# Altium schematic sheet Page 5.SchDoc("Page 5")
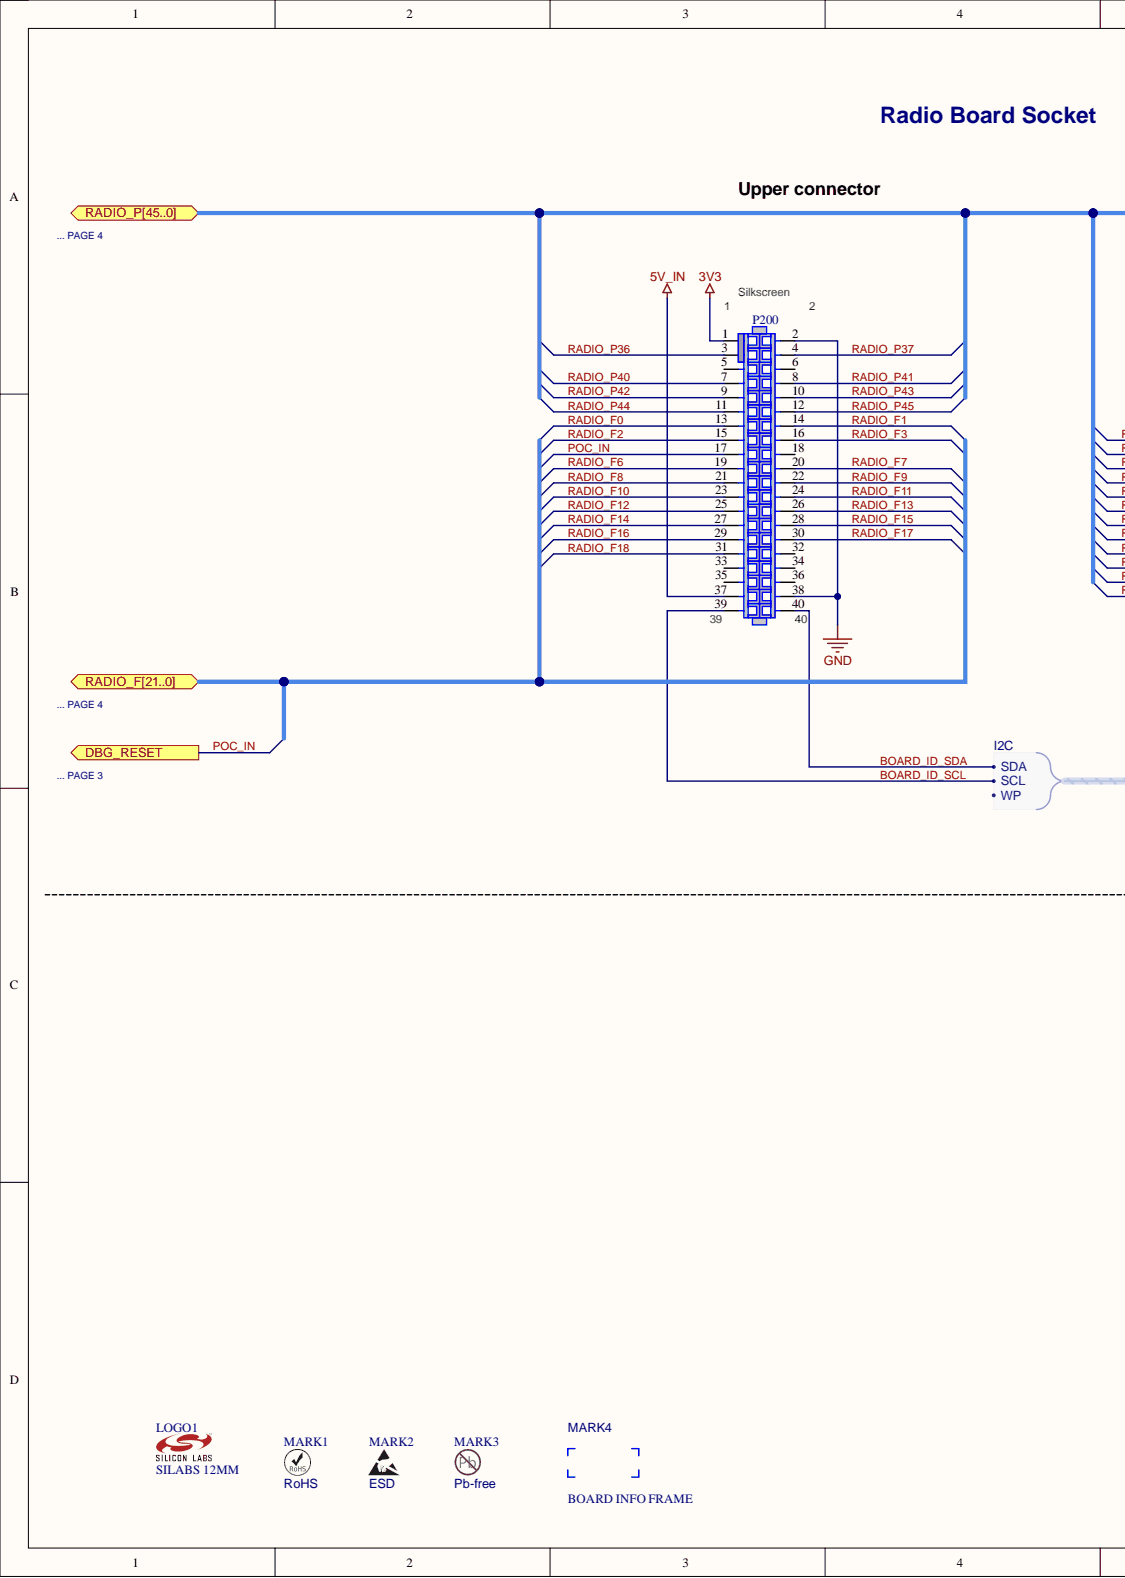
[diagram p0: Page 5.SchDoc, left]
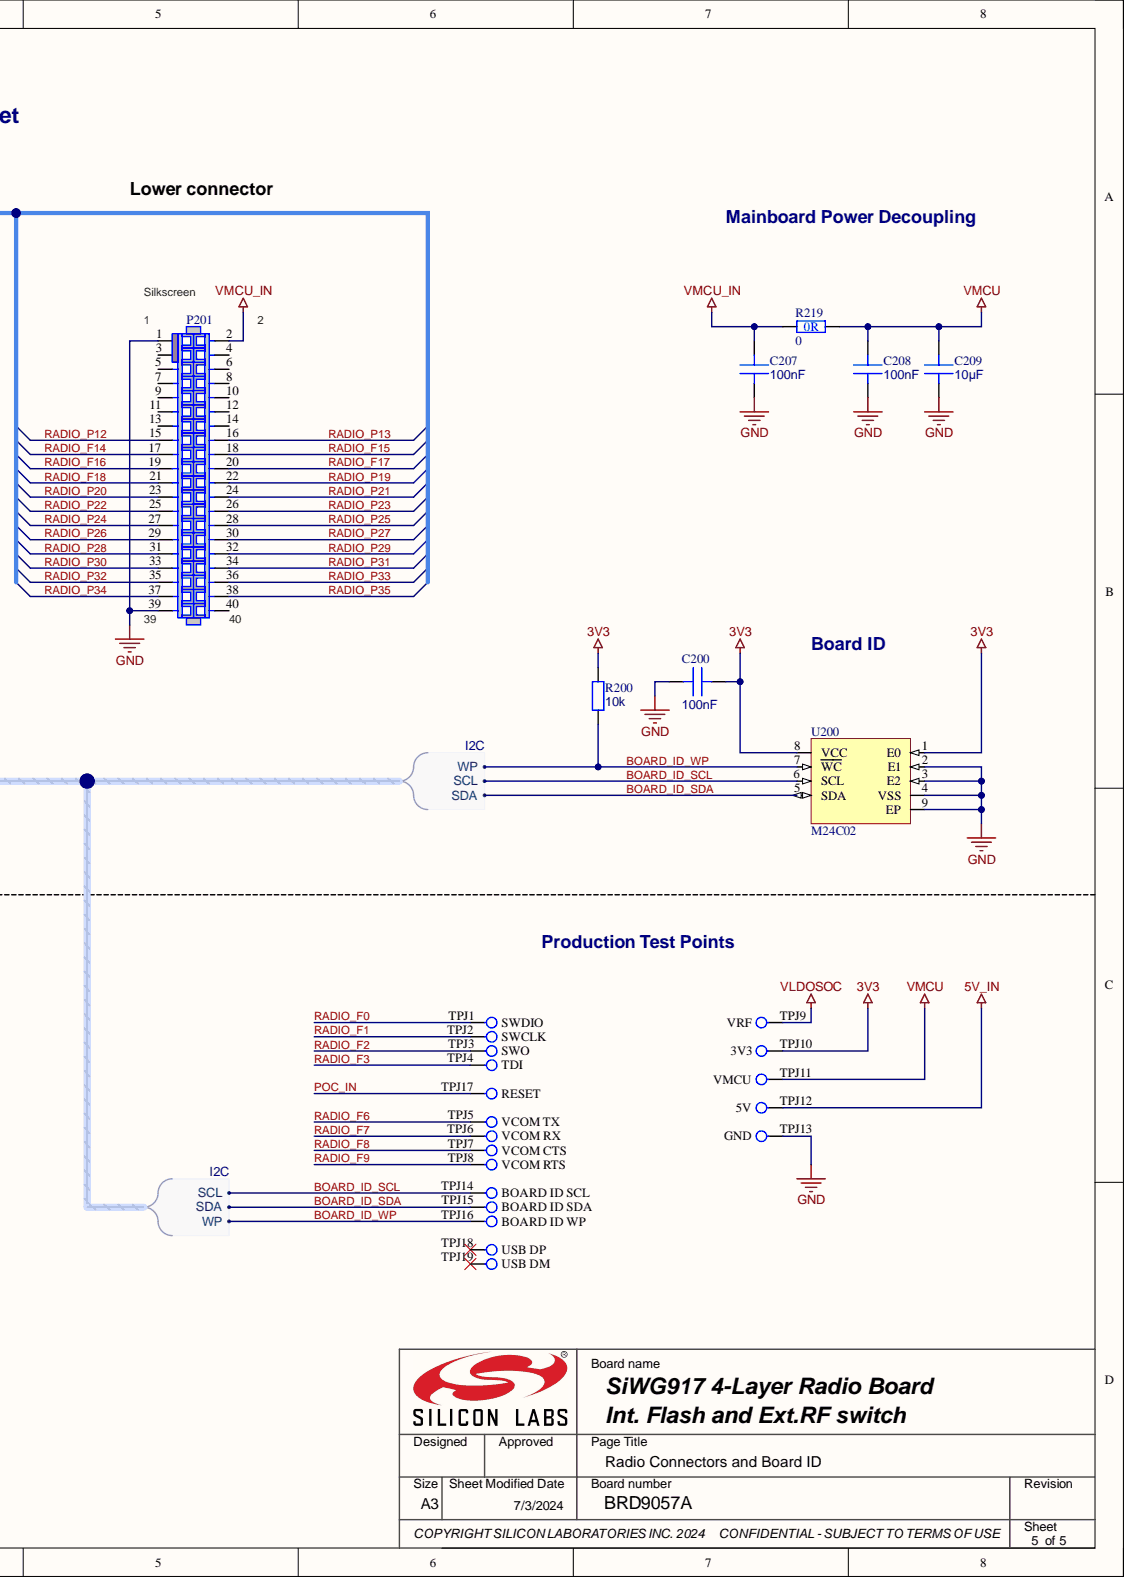
[diagram p1: Page 5.SchDoc, right]

## Parts
C200  value 100nF  [C0201_GM]  (hidden comment: CAP CER 0201 X5R 100nF 16V 10%)
C207  value 100nF  [C0201_GM]  (hidden comment: CAP CER 0201 X5R 100nF 16V 10%)
C208  value 100nF  [C0201_GM]  (hidden comment: CAP CER 0201 X5R 100nF 16V 10%)
C209  value 10µF  [C0603]  (hidden comment: CAP CER 0603 X5R 10uF 16V 10%)
LOGO1  value SILABS 12MM  [LOGO_SILABS_W12.0_SILK]  (hidden comment: LOGO SILABS 12MM)  (no BOM)
M1  RB TEST FIXTURE PATTERN  [RB TEST FIXTURE PATTERN]  (value not drawn)  (no BOM)
MARK1  value RoHS  [LOGO RoHS 5MM]  (hidden comment: LOGO RoHS 5MM)  (no BOM)
MARK2  value ESD  [LOGO ESD 4.5MM]  (hidden comment: LOGO ESD 4.5MM)  (no BOM)
MARK3  value Pb-free  [LOGO PBF 2MM]  (hidden comment: LOGO PBF 2MM)  (no BOM)
MARK4  BOARD INFO FRAME 19MM  value BOARD INFO FRAME  [BOARD INFO FRAME SILK-Footprint-1]  (no BOM)
P200  2344-220MS3CUNR8  [SAMTEC_SFC-120-T2-L-D-A]  (value not drawn)
P201  2344-220MS3CUNR8  [SAMTEC_SFC-120-T2-L-D-A]  (value not drawn)
R200  value 10k  [R0201]  (hidden comment: RES 0201 10K 1/20W 1% 200ppm)
R219  value 0  [R0402]  (hidden comment: RES 0402 0R)
U200  value M24C02  [DFN50P300X200X60_HS-9N_GM]  (hidden comment: M24C02-FMC6TG)

## Ports
DBG_RESET, RADIO_F0, RADIO_F1, RADIO_F2, RADIO_F3, RADIO_F4, RADIO_F5, RADIO_F6, RADIO_F7, RADIO_F8, RADIO_F9, RADIO_F10, RADIO_F11, RADIO_F12, RADIO_F13, RADIO_F14, RADIO_F15, RADIO_F16, RADIO_F17, RADIO_F18, RADIO_F19, RADIO_F20, RADIO_F21, RADIO_F[21..0], RADIO_P0, RADIO_P1, RADIO_P2, RADIO_P3, RADIO_P4, RADIO_P5, RADIO_P6, RADIO_P7, RADIO_P8, RADIO_P9, RADIO_P10, RADIO_P11, RADIO_P12, RADIO_P13, RADIO_P14, RADIO_P15, RADIO_P16, RADIO_P17, RADIO_P18, RADIO_P19, RADIO_P20, RADIO_P21, RADIO_P22, RADIO_P23, RADIO_P24, RADIO_P25, RADIO_P26, RADIO_P27, RADIO_P28, RADIO_P29, RADIO_P30, RADIO_P31, RADIO_P32, RADIO_P33, RADIO_P34, RADIO_P35, RADIO_P36, RADIO_P37, RADIO_P38, RADIO_P39, RADIO_P40, RADIO_P41, RADIO_P42, RADIO_P43, RADIO_P44, RADIO_P45, RADIO_P[45..0]

## Nets
3V3: C200.1 M1.TPJ10(3V3) P200.1 R200.2 U200.1(E0) U200.8(VCC)
5V_IN: M1.TPJ12(5V) P200.37
GND: C200.2 C207.1 C208.1 C209.2 M1.TPJ13(GND) P200.2 P200.38 P201.1 P201.39 U200.2(E1) U200.3(E2) U200.4(VSS) U200.9(EP)
VLDOSOC: M1.TPJ9(VRF)
VMCU: C208.2 C209.1 M1.TPJ11(VMCU) R219.2
VMCU_IN: C207.2 P201.2 R219.1
BOARD_ID_SCL: M1.TPJ14(BOARD_ID_SCL) P200.39 U200.6(SCL)
BOARD_ID_SDA: M1.TPJ15(BOARD_ID_SDA) P200.40 U200.5(SDA)
BOARD_ID_WP: M1.TPJ16(BOARD_ID_WP) R200.1 U200.7(WC)
RADIO_F0: M1.TPJ1(SWDIO) P200.13 ; port RADIO_F[21..0]
RADIO_F1: M1.TPJ2(SWCLK) P200.14 ; port RADIO_F[21..0]
RADIO_F2: M1.TPJ3(SWO) P200.15 ; port RADIO_F[21..0]
RADIO_F3: M1.TPJ4(TDI) P200.16 ; port RADIO_F[21..0]
RADIO_F4: M1.TPJ17(RESET) P200.17 ; port DBG_RESET, port RADIO_F[21..0]
RADIO_F6: M1.TPJ5(VCOM_TX) P200.19 ; port RADIO_F[21..0]
RADIO_F7: M1.TPJ6(VCOM_RX) P200.20 ; port RADIO_F[21..0]
RADIO_F8: M1.TPJ7(VCOM_CTS) P200.21 ; port RADIO_F[21..0]
RADIO_F9: M1.TPJ8(VCOM_RTS) P200.22 ; port RADIO_F[21..0]
RADIO_F10: P200.23 ; port RADIO_F[21..0]
RADIO_F11: P200.24 ; port RADIO_F[21..0]
RADIO_F12: P200.25 ; port RADIO_F[21..0]
RADIO_F13: P200.26 ; port RADIO_F[21..0]
RADIO_F14: P200.27 ; port RADIO_F[21..0]
RADIO_F14: P200.27 ; port RADIO_F[21..0]
RADIO_F15: P200.28 ; port RADIO_F[21..0]
RADIO_F15: P200.28 ; port RADIO_F[21..0]
RADIO_F16: P200.29 ; port RADIO_F[21..0]
RADIO_F16: P200.29 ; port RADIO_F[21..0]
RADIO_F17: P200.30 ; port RADIO_F[21..0]
RADIO_F17: P200.30 ; port RADIO_F[21..0]
RADIO_F18: P200.31 ; port RADIO_F[21..0]
RADIO_F18: P200.31 ; port RADIO_F[21..0]
RADIO_P12: P201.15 ; port RADIO_P[45..0]
RADIO_P13: P201.16 ; port RADIO_P[45..0]
RADIO_P14: P201.17 ; port RADIO_P[45..0]
RADIO_P15: P201.18 ; port RADIO_P[45..0]
RADIO_P16: P201.19 ; port RADIO_P[45..0]
RADIO_P17: P201.20 ; port RADIO_P[45..0]
RADIO_P18: P201.21 ; port RADIO_P[45..0]
RADIO_P19: P201.22 ; port RADIO_P[45..0]
RADIO_P20: P201.23 ; port RADIO_P[45..0]
RADIO_P21: P201.24 ; port RADIO_P[45..0]
RADIO_P22: P201.25 ; port RADIO_P[45..0]
RADIO_P23: P201.26 ; port RADIO_P[45..0]
RADIO_P24: P201.27 ; port RADIO_P[45..0]
RADIO_P25: P201.28 ; port RADIO_P[45..0]
RADIO_P26: P201.29 ; port RADIO_P[45..0]
RADIO_P27: P201.30 ; port RADIO_P[45..0]
RADIO_P28: P201.31 ; port RADIO_P[45..0]
RADIO_P29: P201.32 ; port RADIO_P[45..0]
RADIO_P30: P201.33 ; port RADIO_P[45..0]
RADIO_P31: P201.34 ; port RADIO_P[45..0]
RADIO_P32: P201.35 ; port RADIO_P[45..0]
RADIO_P33: P201.36 ; port RADIO_P[45..0]
RADIO_P34: P201.37 ; port RADIO_P[45..0]
RADIO_P35: P201.38 ; port RADIO_P[45..0]
RADIO_P36: P200.3 ; port RADIO_P[45..0]
RADIO_P37: P200.4 ; port RADIO_P[45..0]
RADIO_P40: P200.7 ; port RADIO_P[45..0]
RADIO_P41: P200.8 ; port RADIO_P[45..0]
RADIO_P42: P200.9 ; port RADIO_P[45..0]
RADIO_P43: P200.10 ; port RADIO_P[45..0]
RADIO_P44: P200.11 ; port RADIO_P[45..0]
RADIO_P45: P200.12 ; port RADIO_P[45..0]
N00002_0: ; port RADIO_P[45..0]
N00002_1: ; port RADIO_P[45..0]
N00002_2: ; port RADIO_P[45..0]
N00002_3: ; port RADIO_P[45..0]
N00002_4: ; port RADIO_P[45..0]
N00002_5: ; port RADIO_P[45..0]
N00002_6: ; port RADIO_P[45..0]
N00002_7: ; port RADIO_P[45..0]
N00002_8: ; port RADIO_P[45..0]
N00002_9: ; port RADIO_P[45..0]
N00002_10: ; port RADIO_P[45..0]
N00002_11: ; port RADIO_P[45..0]
N00002_38: ; port RADIO_P[45..0]
N00002_39: ; port RADIO_P[45..0]
N00004_5: ; port RADIO_F[21..0]
N00004_19: ; port RADIO_F[21..0]
N00004_20: ; port RADIO_F[21..0]
N00004_21: ; port RADIO_F[21..0]

Not connected: M1 TPJ18(USB_DP) TPJ19(USB_DM); P200 5 6 18 32 33 34 35 36; P201 3 4 5 6 7 8 9 10 11 12 13 14 40

## Library data of the parts
C200 C207 C208 = CMP-120-00007-B.06: SubCategory Tag: Ceramic; Component Kind: Standard; Description: CAP CER 0201 X5R 100nF 16V 10%; Library Name: SiLabs Production Server; Material: X5R; Max Operating Temp: 85°C; Min Operating Temp: -55°C; Mount: SMD; Package: 0201; Rating: 16V; RoHS: Compliant; Tolerance: 10%
C209 = CMP-120-00151-A.01: SubCategory Tag: Ceramic; Component Kind: Standard; Description: CAP CER 0603 X5R 10uF 16V 10%; Library Name: SiLabs Production Server; Material: X5R; Max Operating Temp: 85°C; Min Operating Temp: -55°C; Mount: SMD; Package: 0603; Rating: 16V; RoHS: Compliant; Tolerance: 10%
LOGO1 = CMP-000-00112-A.01: Silkscreen logo, Silabs logo and text, B: 12MM, H:6MM; Additional Search Tag: Surface; SubCategory Tag: GRAPH; Library Name: SiLabs Production Server; Mount: N/A
M1 = CMP-000-00032-A.04: Subset of testpoints and keepouts for production test of Radio Boards; Additional Search Tag: Surface; SubCategory Tag: TP; Library Name: SiLabs Production Server; Mount: N/A; Value: TEST FIXTURE PATTERN
MARK1 = CMP-000-00011-A.03: Silkscreen logo, RoHS, 5MM; Additional Search Tag: Surface; SubCategory Tag: GRAPH; Library Name: SiLabs Production Server; Mount: N/A
MARK2 = CMP-000-00009-A.07: Silkscreen logo, ESD, 4.5MM; Additional Search Tag: Surface; SubCategory Tag: GRAPH; Library Name: SiLabs Production Server; Mount: N/A
MARK3 = CMP-000-00010-A.06: Silkscreen logo, lead free, 2MM; Additional Search Tag: Surface; SubCategory Tag: GRAPH; Library Name: SiLabs Production Server; Mount: N/A
MARK4 = CMP-000-00021-A.03: Board info frame 19MM x 6MM, Silkscreen; Additional Search Tag: Surface; SubCategory Tag: GRAPH; Library Name: SiLabs Production Server; Mount: N/A
P200 P201 = CMP-125-00164-A.12: SOCKET 2X20 1.27MM SMD VRT KEYED; Manufacturer: WCON; Additional Search Tag: Female; No of Positions: 40; No of Rows: 2; Note: Samtec footprint made as default as used for radio boards; SubCategory Tag: Header 1.27mm; Component Kind: Standard; Library Name: SiLabs Production Server; Manufacturer PN: 2344-220MS3CUNR8; Max Operating Temp: 105°C; Min Operating Temp: -40°C; Mount: SMD; Package: HDR 2X20 1.27MM; RoHS: Compliant; Value: 2344-220MS3CUNR8
R200 = CMP-180-00002-A.10: Rating: 50mW; SubCategory Tag: Thick Film; Component Kind: Standard; Description: RES 0201 10K 1/20W 1% 200ppm; Library Name: SiLabs Production Server; Max Operating Temp: 125°C; Min Operating Temp: -55°C; Mount: SMD; Package: 0201; RoHS: Compliant; Tolerance: 1%
R219 = CMP-180-00061-B.02: Rating: 62.5mW; SubCategory Tag: Thick Film; Tolerance: 0%; Component Kind: Standard; Description: RES 0402 0R; Library Name: SiLabs Production Server; Max Operating Temp: 155°C; Min Operating Temp: -55°C; Mount: SMD; Package: 0402; RoHS: Compliant
U200 = CMP-150-00051-B.01: IC EEPROM 2KBIT I2C UFDFPN8; Manufacturer: ST Microelectronics; SubCategory Tag: Memory; Voltage: 5.5V; Additional Search Tag: EEPROM; Component Kind: Standard; Library Name: SiLabs Production Server; Manufacturer PN: M24C02-FMC6TG; Max Operating Temp: 85°C; Min Operating Temp: -40°C; Mount: SMD; Package: UFDFPN8; RoHS: Compliant
